# Altium schematic sheet Digital Output Shield.SchDoc
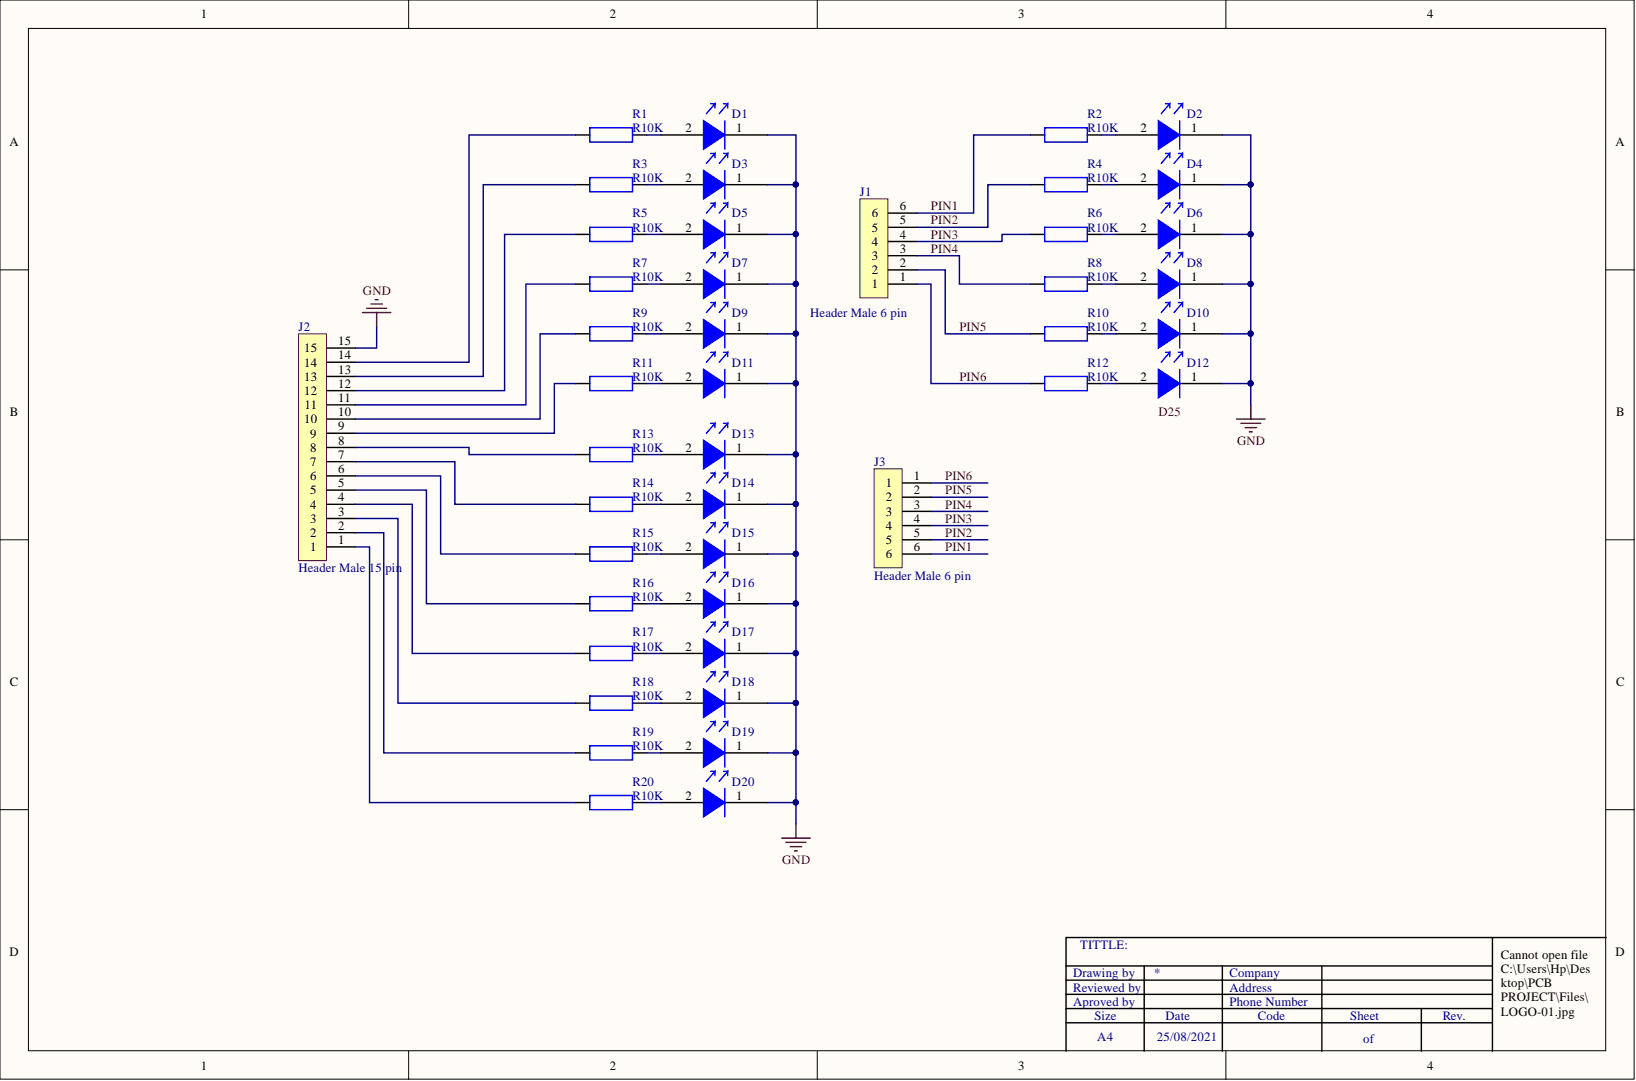
[diagram p0: Digital Output Shield.SchDoc]

## Parts
D1  Diode_led_Green  [Diode_led_green_3mm]  (value not drawn)
D2  Diode_led_Green  [Diode_led_green_3mm]  (value not drawn)
D3  Diode_led_Green  [Diode_led_green_3mm]  (value not drawn)
D4  Diode_led_Green  [Diode_led_green_3mm]  (value not drawn)
D5  Diode_led_Green  [Diode_led_green_3mm]  (value not drawn)
D6  Diode_led_Green  [Diode_led_green_3mm]  (value not drawn)
D7  Diode_led_Green  [Diode_led_green_3mm]  (value not drawn)
D8  Diode_led_Green  [Diode_led_green_3mm]  (value not drawn)
D9  Diode_led_Green  [Diode_led_green_3mm]  (value not drawn)
D10  Diode_led_Green  [Diode_led_green_3mm]  (value not drawn)
D11  Diode_led_Green  [Diode_led_green_3mm]  (value not drawn)
D12  Diode_led_Green  [Diode_led_green_3mm]  (value not drawn)
D13  Diode_led_Green  [Diode_led_green_3mm]  (value not drawn)
D14  Diode_led_Green  [Diode_led_green_3mm]  (value not drawn)
D15  Diode_led_Green  [Diode_led_green_3mm]  (value not drawn)
D16  Diode_led_Green  [Diode_led_green_3mm]  (value not drawn)
D17  Diode_led_Green  [Diode_led_green_3mm]  (value not drawn)
D18  Diode_led_Green  [Diode_led_green_3mm]  (value not drawn)
D19  Diode_led_Green  [Diode_led_green_3mm]  (value not drawn)
D20  Diode_led_Green  [Diode_led_green_3mm]  (value not drawn)
J1  Header Male 6 pin  [PIN_HEADER_6_FEMALE]
J2  Header Male 15 pin  [PIN_HEADER_10_FEMALE]
J3  Header Male 6 pin  [PIN_HEADER_6_FEMALE]
R1  R10K  [R10K_P8mm_0.25Watt]
R2  R10K  [R10K_P8mm_0.25Watt]
R3  R10K  [R10K_P8mm_0.25Watt]
R4  R10K  [R10K_P8mm_0.25Watt]
R5  R10K  [R10K_P8mm_0.25Watt]
R6  R10K  [R10K_P8mm_0.25Watt]
R7  R10K  [R10K_P8mm_0.25Watt]
R8  R10K  [R10K_P8mm_0.25Watt]
R9  R10K  [R10K_P8mm_0.25Watt]
R10  R10K  [R10K_P8mm_0.25Watt]
R11  R10K  [R10K_P8mm_0.25Watt]
R12  R10K  [R10K_P8mm_0.25Watt]
R13  R10K  [R10K_P8mm_0.25Watt]
R14  R10K  [R10K_P8mm_0.25Watt]
R15  R10K  [R10K_P8mm_0.25Watt]
R16  R10K  [R10K_P8mm_0.25Watt]
R17  R10K  [R10K_P8mm_0.25Watt]
R18  R10K  [R10K_P8mm_0.25Watt]
R19  R10K  [R10K_P8mm_0.25Watt]
R20  R10K  [R10K_P8mm_0.25Watt]

## Nets
GND: D1.1 D2.1 D3.1 D4.1 D5.1 D6.1 D7.1 D8.1 D9.1 D10.1 D11.1 D12.1 D13.1 D14.1 D15.1 D16.1 D17.1 D18.1 D19.1 D20.1 J2.15
PIN1: J1.6 J3.6 R2.1
PIN2: J1.5 J3.5 R4.1
PIN3: J1.4 J3.4 R6.1
PIN4: J1.3 J3.3 R8.1
PIN5: J1.2 J3.2 R10.1
PIN6: J1.1 J3.1 R12.1
NetD1_2: D1.2 R1.2
NetD2_2: D2.2 R2.2
NetD3_2: D3.2 R3.2
NetD4_2: D4.2 R4.2
NetD5_2: D5.2 R5.2
NetD6_2: D6.2 R6.2
NetD7_2: D7.2 R7.2
NetD8_2: D8.2 R8.2
NetD9_2: D9.2 R9.2
NetD10_2: D10.2 R10.2
NetD11_2: D11.2 R11.2
NetD12_2: D12.2 R12.2
NetD13_2: D13.2 R13.2
NetD14_2: D14.2 R14.2
NetD15_2: D15.2 R15.2
NetD16_2: D16.2 R16.2
NetD17_2: D17.2 R17.2
NetD18_2: D18.2 R18.2
NetD19_2: D19.2 R19.2
NetD20_2: D20.2 R20.2
NetJ2_1: J2.1 R20.1
NetJ2_2: J2.2 R19.1
NetJ2_3: J2.3 R18.1
NetJ2_4: J2.4 R17.1
NetJ2_5: J2.5 R16.1
NetJ2_6: J2.6 R15.1
NetJ2_7: J2.7 R14.1
NetJ2_8: J2.8 R13.1
NetJ2_9: J2.9 R11.1
NetJ2_10: J2.10 R9.1
NetJ2_11: J2.11 R7.1
NetJ2_12: J2.12 R5.1
NetJ2_13: J2.13 R3.1
NetJ2_14: J2.14 R1.1
D25:

## Library data of the parts
D1-D20 = Diode_led_Green_3mm: Component Kind: Standard; Library Name: Digital Output Shield.SchLib
J1 J3 = Header Male 6: Component Kind: Standard; Library Name: Digital Output Shield.SchLib
J2 = Header Male 15: Component Kind: Standard; Library Name: Digital Output Shield.SchLib
R1-R20 = R10K: Component Kind: Standard; Library Name: Digital Output Shield.SchLib
